# Altium schematic sheet pmod_vga.SchDoc
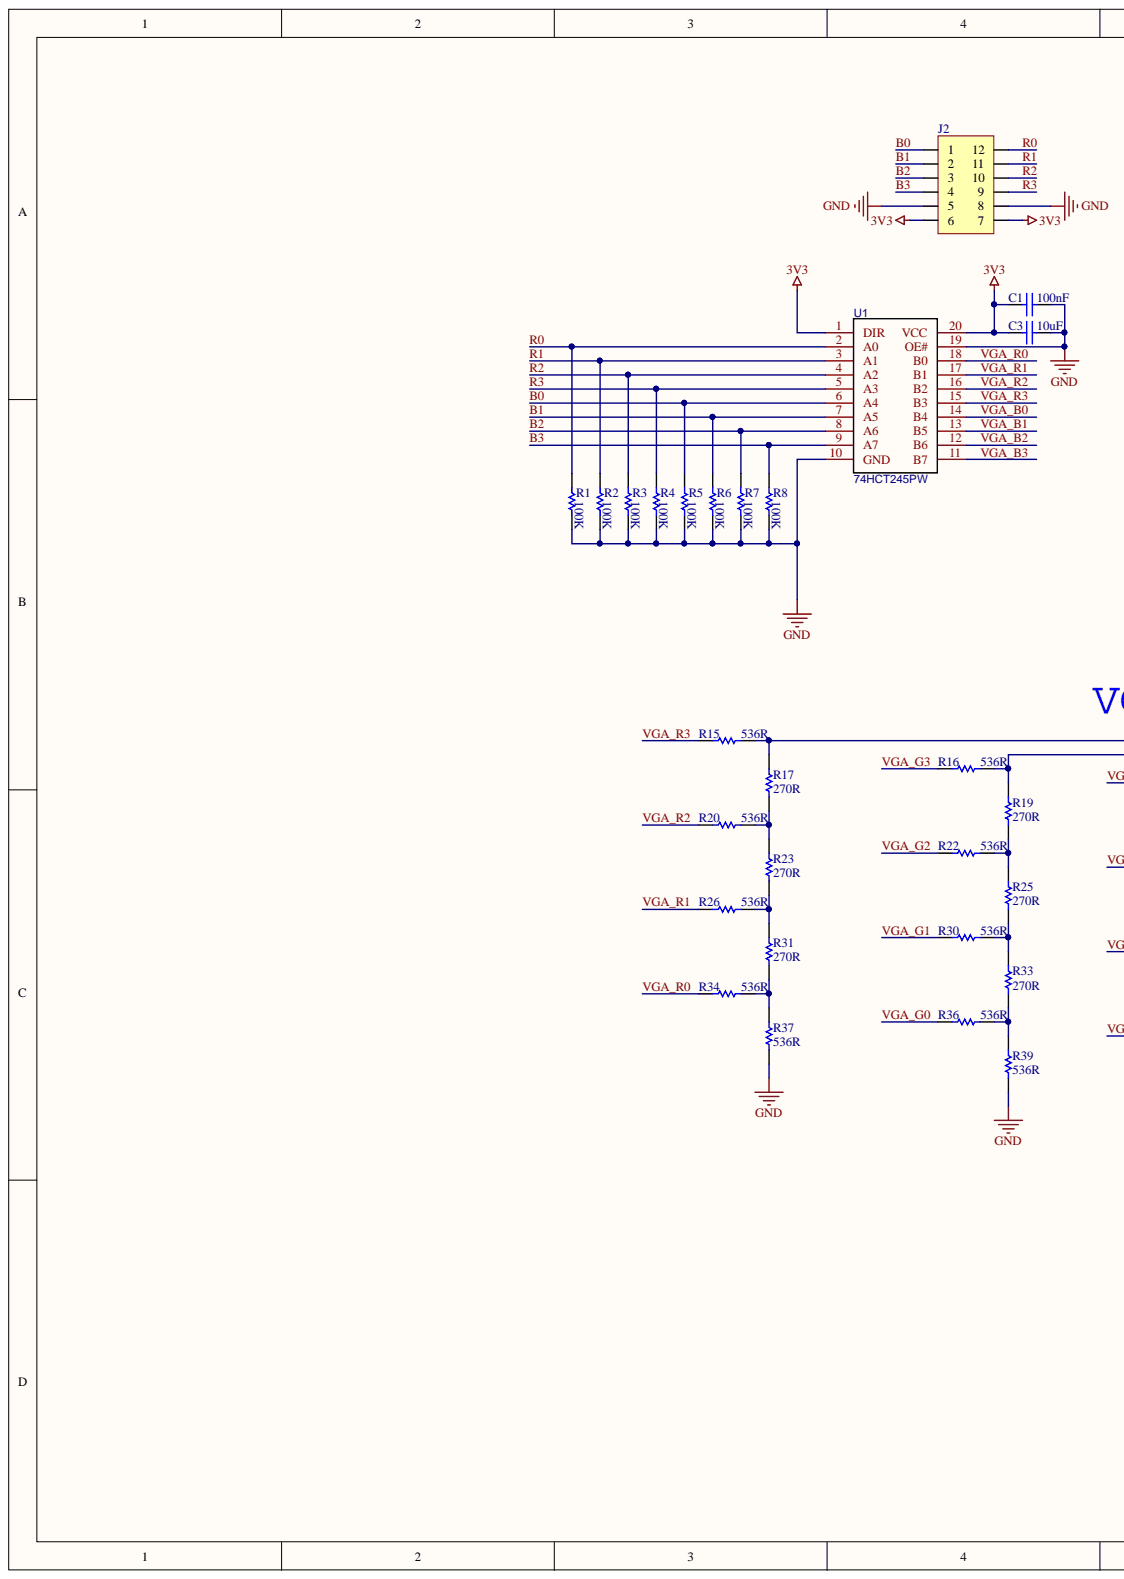
[diagram p0: pmod_vga.SchDoc, left]
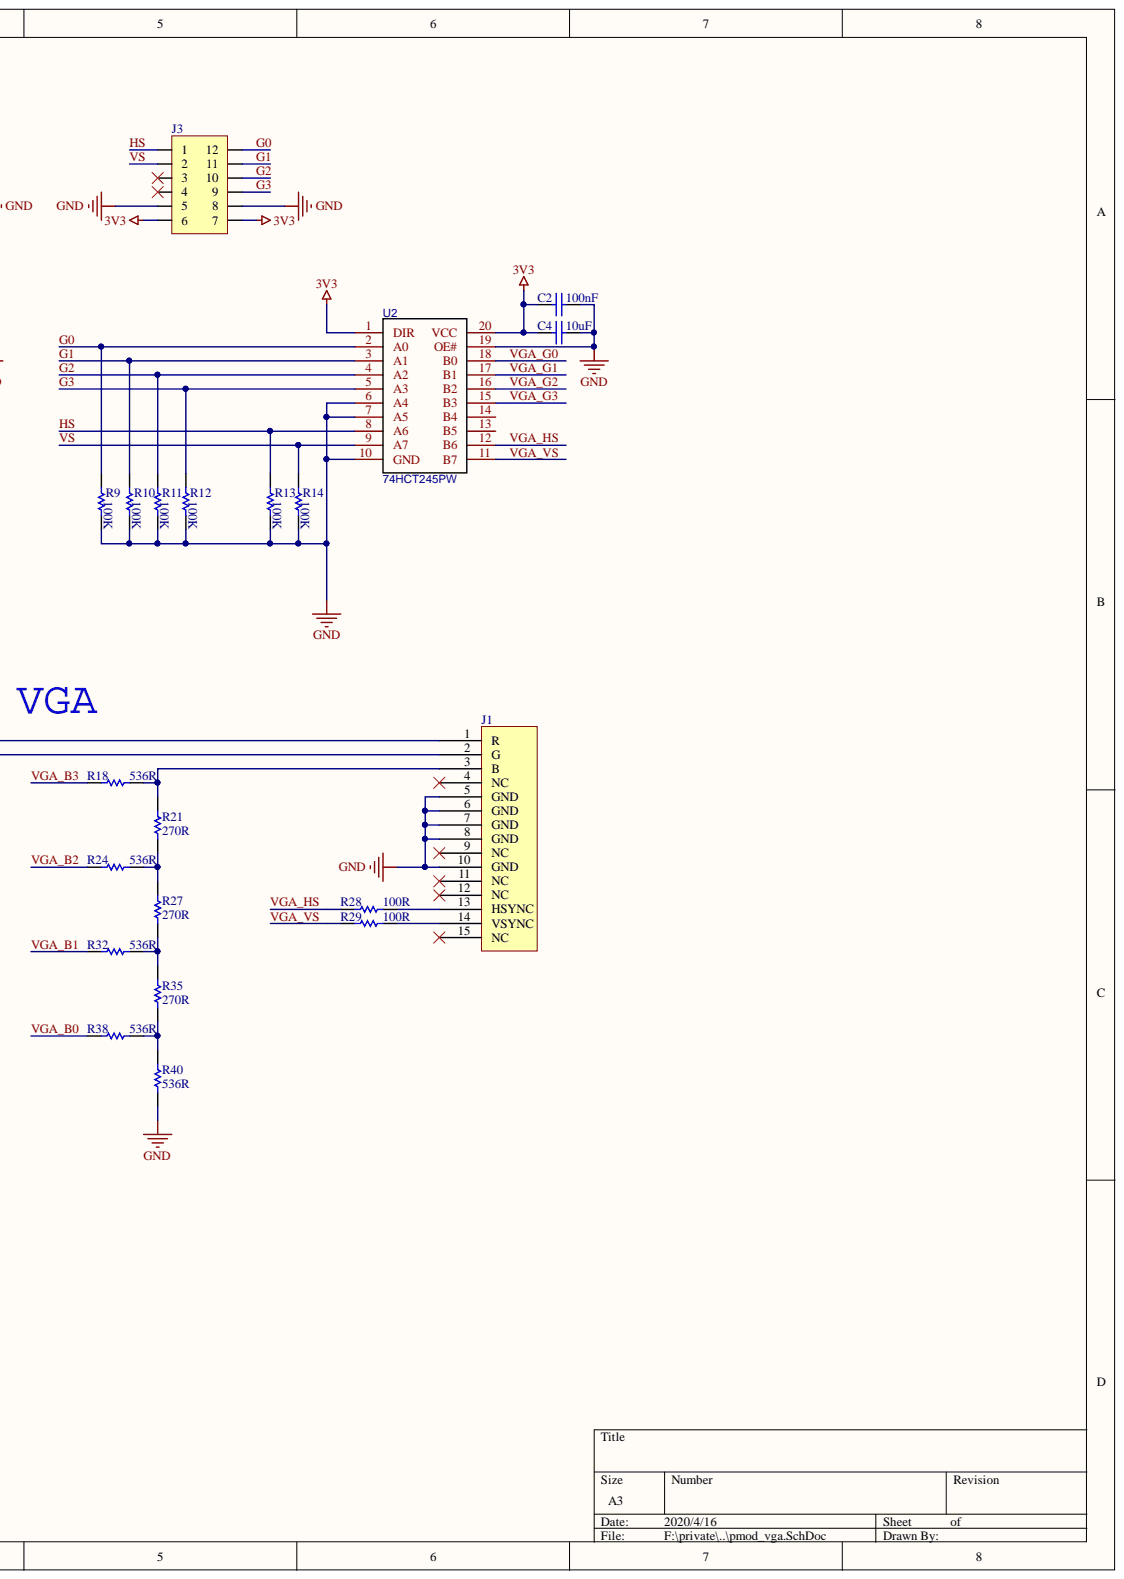
[diagram p1: pmod_vga.SchDoc, right]

## Parts
C1  value 100nF  [0603_C]  (hidden comment: C0603)
C2  value 100nF  [0603_C]  (hidden comment: C0603)
C3  value 10uF  [0603_C]  (hidden comment: C0603)
C4  value 10uF  [0603_C]  (hidden comment: C0603)
J1  VGA_FEMALE  [VGA15B]  (value not drawn)
J2  (no value)  [HEADER_SMT_6]
J3  (no value)  [HEADER_SMT_6]
R1  value 100K  [0603_R]  (hidden comment: R0603)
R2  value 100K  [0603_R]  (hidden comment: R0603)
R3  value 100K  [0603_R]  (hidden comment: R0603)
R4  value 100K  [0603_R]  (hidden comment: R0603)
R5  value 100K  [0603_R]  (hidden comment: R0603)
R6  value 100K  [0603_R]  (hidden comment: R0603)
R7  value 100K  [0603_R]  (hidden comment: R0603)
R8  value 100K  [0603_R]  (hidden comment: R0603)
R9  value 100K  [0603_R]  (hidden comment: R0603)
R10  value 100K  [0603_R]  (hidden comment: R0603)
R11  value 100K  [0603_R]  (hidden comment: R0603)
R12  value 100K  [0603_R]  (hidden comment: R0603)
R13  value 100K  [0603_R]  (hidden comment: R0603)
R14  value 100K  [0603_R]  (hidden comment: R0603)
R15  value 536R  [0603_R]  (hidden comment: R0603)
R16  value 536R  [0603_R]  (hidden comment: R0603)
R17  value 270R  [0603_R]  (hidden comment: R0603)
R18  value 536R  [0603_R]  (hidden comment: R0603)
R19  value 270R  [0603_R]  (hidden comment: R0603)
R20  value 536R  [0603_R]  (hidden comment: R0603)
R21  value 270R  [0603_R]  (hidden comment: R0603)
R22  value 536R  [0603_R]  (hidden comment: R0603)
R23  value 270R  [0603_R]  (hidden comment: R0603)
R24  value 536R  [0603_R]  (hidden comment: R0603)
R25  value 270R  [0603_R]  (hidden comment: R0603)
R26  value 536R  [0603_R]  (hidden comment: R0603)
R27  value 270R  [0603_R]  (hidden comment: R0603)
R28  value 100R  [0603_R]  (hidden comment: R0603)
R29  value 100R  [0603_R]  (hidden comment: R0603)
R30  value 536R  [0603_R]  (hidden comment: R0603)
R31  value 270R  [0603_R]  (hidden comment: R0603)
R32  value 536R  [0603_R]  (hidden comment: R0603)
R33  value 270R  [0603_R]  (hidden comment: R0603)
R34  value 536R  [0603_R]  (hidden comment: R0603)
R35  value 270R  [0603_R]  (hidden comment: R0603)
R36  value 536R  [0603_R]  (hidden comment: R0603)
R37  value 536R  [0603_R]  (hidden comment: R0603)
R38  value 536R  [0603_R]  (hidden comment: R0603)
R39  value 536R  [0603_R]  (hidden comment: R0603)
R40  value 536R  [0603_R]  (hidden comment: R0603)
U1  74HCT245PW  [TSSOP20_L]
U2  74HCT245PW  [TSSOP20_L]

## Nets
3V3: C1.2 C2.2 C3.2 C4.2 J2.6 J2.7 J3.6 J3.7 U1.1(DIR) U1.20(VCC) U2.1(DIR) U2.20(VCC)
GND: C1.1 C2.1 C3.1 C4.1 J1.5(GND) J1.6(GND) J1.7(GND) J1.8(GND) J1.10(GND) J2.5 J2.8 J3.5 J3.8 R1.2 R2.2 R3.2 R4.2 R5.2 R6.2 R7.2 R8.2 R9.2 R10.2 R11.2 R12.2 R13.2 R14.2 R37.1 R39.1 R40.1 U1.10(GND) U1.19(OE#) U2.6(A4) U2.7(A5) U2.10(GND) U2.19(OE#)
B0: J2.1 R5.1 U1.6(A4)
B1: J2.2 R6.1 U1.7(A5)
B2: J2.3 R7.1 U1.8(A6)
B3: J2.4 R8.1 U1.9(A7)
G0: J3.12 R9.1 U2.2(A0)
G1: J3.11 R10.1 U2.3(A1)
G2: J3.10 R11.1 U2.4(A2)
G3: J3.9 R12.1 U2.5(A3)
HS: J3.1 R13.1 U2.8(A6)
R0: J2.12 R1.1 U1.2(A0)
R1: J2.11 R2.1 U1.3(A1)
R2: J2.10 R3.1 U1.4(A2)
R3: J2.9 R4.1 U1.5(A3)
VGA_B0: R38.1 U1.14(B4)
VGA_B1: R32.1 U1.13(B5)
VGA_B2: R24.1 U1.12(B6)
VGA_B3: R18.1 U1.11(B7)
VGA_G0: R36.1 U2.18(B0)
VGA_G1: R30.1 U2.17(B1)
VGA_G2: R22.1 U2.16(B2)
VGA_G3: R16.1 U2.15(B3)
VGA_HS: R28.1 U2.12(B6)
VGA_R0: R34.1 U1.18(B0)
VGA_R1: R26.1 U1.17(B1)
VGA_R2: R20.1 U1.16(B2)
VGA_R3: R15.1 U1.15(B3)
VGA_VS: R29.1 U2.11(B7)
VS: J3.2 R14.1 U2.9(A7)
NetJ1_1: J1.1(R) R15.2 R17.2
NetJ1_2: J1.2(G) R16.2 R19.2
NetJ1_3: J1.3(B) R18.2 R21.2
NetJ1_13: J1.13(HSYNC) R28.2
NetJ1_14: J1.14(VSYNC) R29.2
NetR17_1: R17.1 R20.2 R23.2
NetR19_1: R19.1 R22.2 R25.2
NetR21_1: R21.1 R24.2 R27.2
NetR23_1: R23.1 R26.2 R31.2
NetR25_1: R25.1 R30.2 R33.2
NetR27_1: R27.1 R32.2 R35.2
NetR31_1: R31.1 R34.2 R37.2
NetR33_1: R33.1 R36.2 R39.2
NetR35_1: R35.1 R38.2 R40.2

Not connected: J1 4(NC) 9(NC) 11(NC) 12(NC) 15(NC); J3 3 4; U2 13(B5) 14(B4)

## Library data of the parts
C1-C4 = C0603: Capacitor; Component Kind: Standard; LatestRevisionDate: 17-Jul-2002; LatestRevisionNote: Re-released for DXP Platform.; Library Name: MYLIB.IntLib; PackageDescription: Radial Cap, Thru-Hole; 2 Leads; 0.3 in Pin Spacing; PackageReference: RAD-0.3; Published: 8-Jun-2000; Signal Integrity: Cap; Simulation: CAP; Publisher: Altium Limited
J2 J3 = Header 2x6: Header, 4-Pin; Component Kind: Standard; LatestRevisionDate: 17-Jul-2002; LatestRevisionNote: Re-released for DXP Platform.; Signal Integrity: Connector; Library Name: pmod_vga.SCHLIB; Publisher: Altium Limited
R1-R40 = R0603: Resistor; Component Kind: Standard; LatestRevisionDate: 17-Jul-2002; LatestRevisionNote: Re-released for DXP Platform.; Library Name: MYLIB.IntLib; PackageDescription: Axial Device, Thru-Hole; 2 Leads; 0.3 in Pin Spacing; PackageReference: AXIAL-0.3; Published: 8-Jun-2000; Signal Integrity: Res; Simulation: RESISTOR; Publisher: Altium Limited
U1 U2 = 74HCT245PW: BOM_Manufacturer: PHILIPS; SMT Type: Extend; BOM_LCSC Assembly: Yes; BOM_Manufacturer Part: 74HCT245PW; BOM_Supplier: LCSC; BOM_Supplier Part: C5980; Component Kind: Standard; image: //image.lceda.cn/szlcsc/C5980.jpg; spicePre: U; spiceSymbolName: 74HCT245PW; Library Name: pmod_vga.SCHLIB
J1 = VGA_FEMALE: Component Kind: Standard; Library Name: nanoDAP.SCHLIB
